# Altium schematic sheet POWER.SchDoc(POWER)
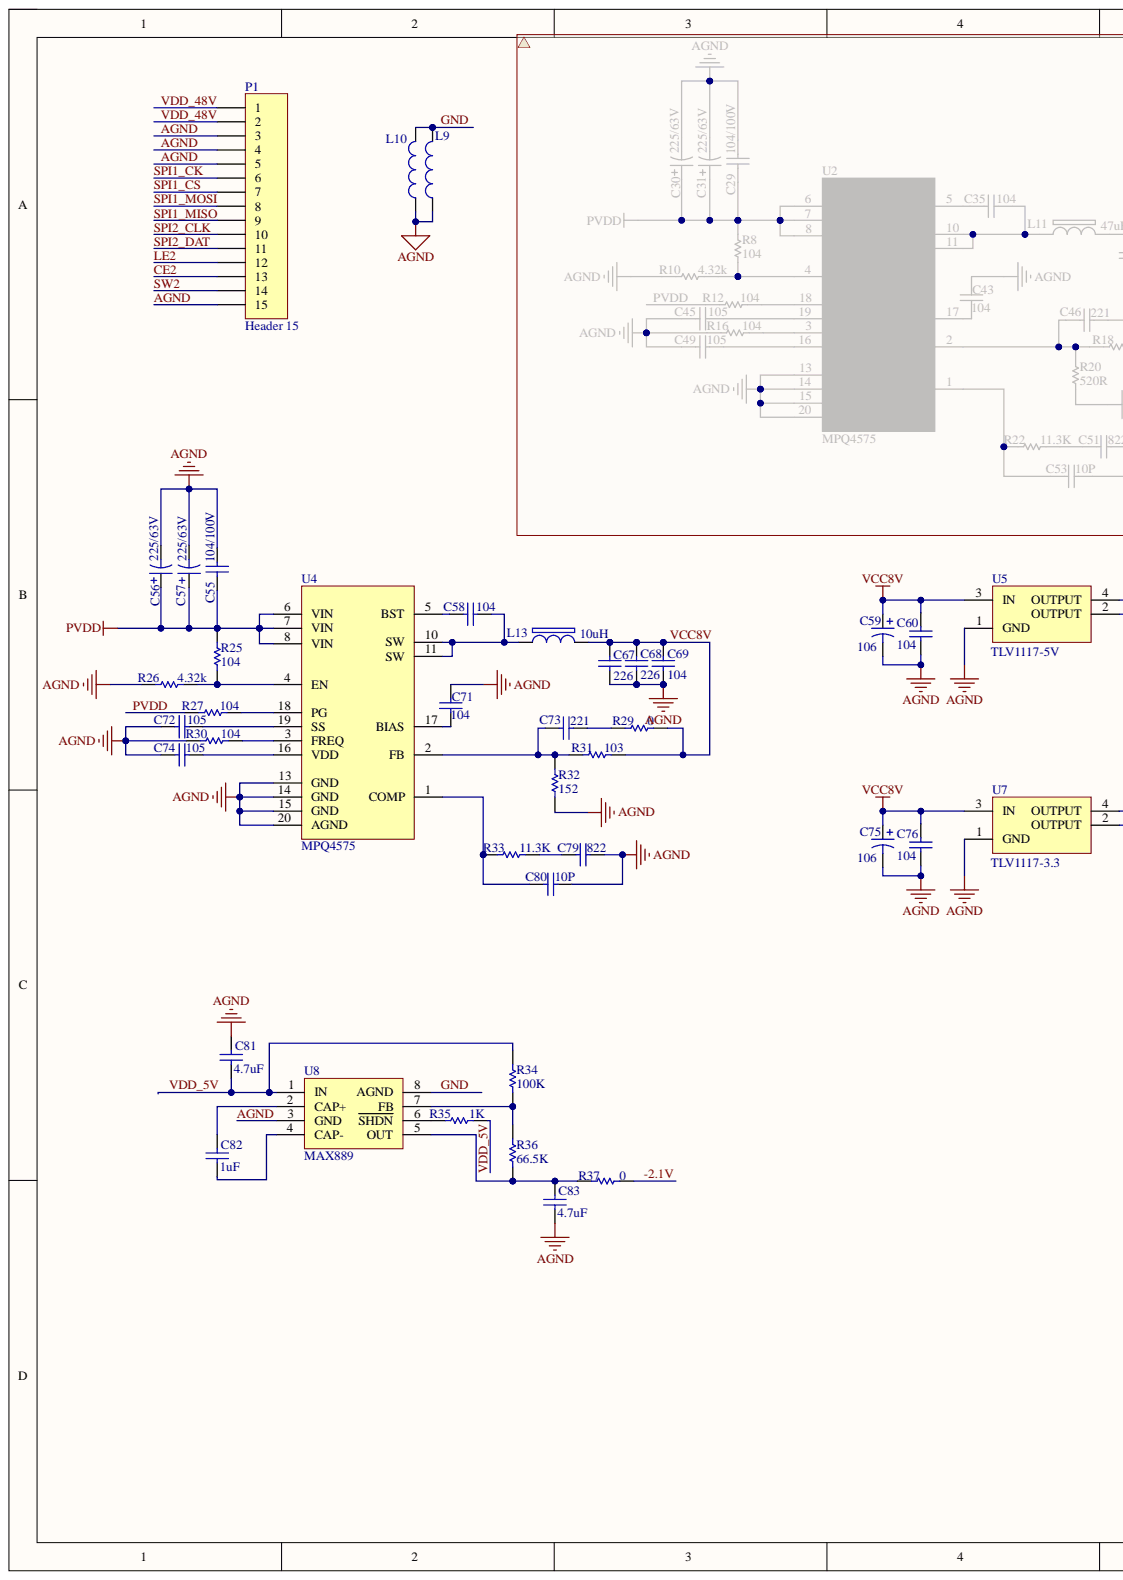
[diagram p0: POWER.SchDoc, left]
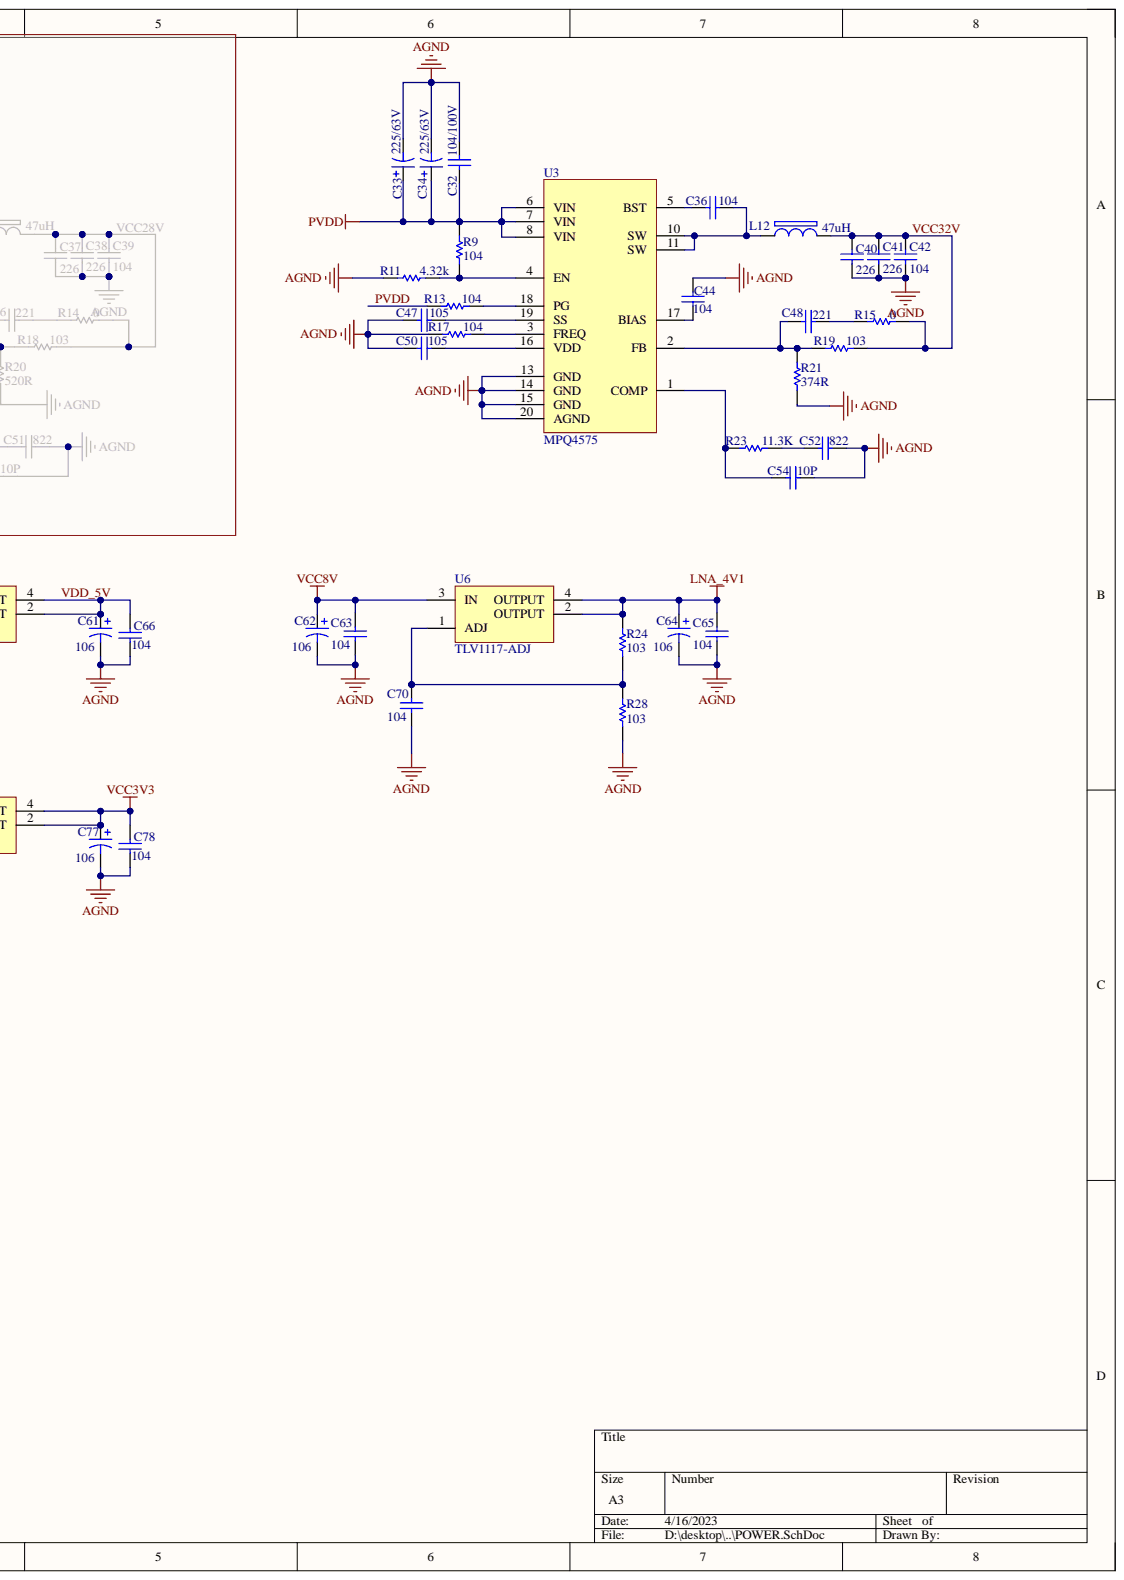
[diagram p1: POWER.SchDoc, right]

## Parts
C32  104/100V  [0603C]
C33  225/63V  [C 1812_L]
C34  225/63V  [C 1812_L]
C36  104  [0603C]
C40  226  [C 1812_L]
C41  226  [C 1812_L]
C42  104  [0603C]
C44  104  [0603C]
C47  105  [0603C]
C48  221  [0603C]
C50  105  [0603C]
C52  822  [0603C]
C54  10P  [0603C]
C55  104/100V  [0603C]
C56  225/63V  [C 1812_L]
C57  225/63V  [C 1812_L]
C58  104  [0603C]
C59  106  [C 1206_L]
C60  104  [0603C]
C61  106  [C 1206_L]
C62  106  [C 1206_L]
C63  104  [0603C]
C64  106  [C 1206_L]
C65  104  [0603C]
C66  104  [0603C]
C67  226  [C 1812_L]
C68  226  [C 1812_L]
C69  104  [0603C]
C70  104  [0603C]
C71  104  [0603C]
C72  105  [0603C]
C73  221  [0603C]
C74  105  [0603C]
C75  106  [C 1206_L]
C76  104  [0603C]
C77  106  [C 1206_L]
C78  104  [0603C]
C79  822  [0603C]
C80  10P  [0603C]
C81  4.7uF  [0603C]
C82  1uF  [0603C]
C83  4.7uF  [0603C]
L9  (no value)  [0603L]
L10  (no value)  [0603L]
L12  47uH  [CD106]
L13  10uH  [CD53]
P1  Header 15  [MDC1-15SW1]
R9  104  [0603R]
R11  4.32k  [0603R]
R13  104  [0603R]
R15  0  [0603R]
R17  104  [0603R]
R19  103  [0603R]
R21  374R  [0603R]
R23  11.3K  [0603R]
R24  103  [0603R]
R25  104  [0603R]
R26  4.32k  [0603R]
R27  104  [0603R]
R28  103  [0603R]
R29  0  [0603R]
R30  104  [0603R]
R31  103  [0603R]
R32  152  [0603R]
R33  11.3K  [0603R]
R34  100K  [0603R]
R35  1K  [0603R]
R36  66.5K  [0603R]
R37  0  [0603R]
U3  MPQ4575  [TSSOP-20]
U4  MPQ4575  [TSSOP-20]
U5  TLV1117-5V  [SOT223]
U6  TLV1117-ADJ  [SOT223]
U7  TLV1117-3.3  [SOT223]
U8  MAX889  [SOIC-8]

## Nets
LNA_4V1: C64.1 C65.2 R24.1 U6.2(OUTPUT) U6.4(OUTPUT)
VCC3V3: C77.1 C78.2 U7.2(OUTPUT) U7.4(OUTPUT)
-2.1V: R37.2
AGND: C32.2 C33.2 C34.2 C40.2 C41.2 C42.2 C44.2 C47.2 C50.2 C52.1 C54.1 C55.2 C56.2 C57.2 C59.2 C60.1 C61.2 C62.2 C63.1 C64.2 C65.1 C66.1 C67.2 C68.2 C69.2 C70.1 C71.2 C72.2 C74.2 C75.2 C76.1 C77.2 C78.1 C79.1 C80.1 C81.1 C83.2 L9.1 L10.1 P1.3 P1.4 P1.5 P1.15 R11.2 R17.2 R21.1 R26.2 R28.2 R30.2 R32.1 U3.13(GND) U3.14(GND) U3.15(GND) U3.20(AGND) U4.13(GND) U4.14(GND) U4.15(GND) U4.20(AGND) U5.1(GND) U7.1(GND) U8.3(GND)
CE2: P1.13
GND: L9.2 L10.2 U8.8(AGND)
LE2: P1.12
PVDD: C32.1 C33.1 C34.1 C55.1 C56.1 C57.1 R9.2 R13.2 R25.2 R27.2 U3.6(VIN) U3.7(VIN) U3.8(VIN) U4.6(VIN) U4.7(VIN) U4.8(VIN)
SPI1_CK: P1.6
SPI1_CS: P1.7
SPI1_MISO: P1.9
SPI1_MOSI: P1.8
SPI2_CLK: P1.10
SPI2_DAT: P1.11
SW2: P1.14
VCC8V: C59.1 C60.2 C62.1 C63.2 C67.1 C68.1 C69.1 C75.1 C76.2 L13.2 R29.1 R31.1 U5.3(IN) U6.3(IN) U7.3(IN)
VCC32V: C40.1 C41.1 C42.1 L12.2 R15.1 R19.1
VDD_5V: C61.1 C66.2 C81.2 R34.2 R35.1 U5.2(OUTPUT) U5.4(OUTPUT) U8.1(IN)
VDD_48V: P1.1 P1.2
NetC36_1: C36.1 U3.5(BST)
NetC36_2: C36.2 L12.1 U3.10(SW) U3.11(SW)
NetC44_1: C44.1 U3.17(BIAS)
NetC47_1: C47.1 U3.19(SS)
NetC48_1: C48.1 R15.2
NetC48_2: C48.2 R19.2 R21.2 U3.2(FB)
NetC50_1: C50.1 U3.16(VDD)
NetC52_2: C52.2 R23.1
NetC54_2: C54.2 R23.2 U3.1(COMP)
NetC58_1: C58.1 U4.5(BST)
NetC58_2: C58.2 L13.1 U4.10(SW) U4.11(SW)
NetC70_2: C70.2 R24.2 R28.1 U6.1(ADJ)
NetC71_1: C71.1 U4.17(BIAS)
NetC72_1: C72.1 U4.19(SS)
NetC73_1: C73.1 R29.2
NetC73_2: C73.2 R31.2 R32.2 U4.2(FB)
NetC74_1: C74.1 U4.16(VDD)
NetC79_2: C79.2 R33.1
NetC80_2: C80.2 R33.2 U4.1(COMP)
NetC82_1: C82.1 U8.2(CAP+)
NetC82_2: C82.2 U8.4(CAP-)
NetC83_1: C83.1 R36.1 R37.1 U8.5(OUT)
NetR9_1: R9.1 R11.1 U3.4(EN)
NetR13_1: R13.1 U3.18(PG)
NetR17_1: R17.1 U3.3(FREQ)
NetR25_1: R25.1 R26.1 U4.4(EN)
NetR27_1: R27.1 U4.18(PG)
NetR30_1: R30.1 U4.3(FREQ)
NetR34_1: R34.1 R36.2 U8.7(FB)
NetR35_2: R35.2 U8.6(SHDN)

## Library data of the parts
C32 C36 C42 C44 C55 C58 C60 C63 C65 C66 C69-C71 C76 C78 = Cap: 08050001; Value: 100pF; Component Kind: Standard; LatestRevisionDate: 17-Jul-2002; LatestRevisionNote: Re-released for DXP Platform.; Library Name: Miscellaneous Devices.IntLib; PackageDescription: Radial Cap, Thru-Hole; 2 Leads; 0.3 in Pin Spacing; PackageReference: RAD-0.3; Published: 8-Jun-2000; Signal Integrity: Cap; Simulation: CAP; Publisher: Altium Limited
C33 C34 C56 C57 = Cap Pol1: 08070019; Value: 100pF; Component Kind: Standard; LatestRevisionDate: 17-Jul-2002; LatestRevisionNote: Re-released for DXP Platform.; Library Name: Miscellaneous Devices.IntLib; PackageDescription: Polarized Capacitor (Radial); 2 Leads; PackageReference: RB7.6-15; Published: 8-Jun-2000; Signal Integrity: Cap Pol; Simulation: CAP; Publisher: Altium Limited
C40 C41 C67 C68 = Cap: 08050051; Value: 100pF; Component Kind: Standard; LatestRevisionDate: 17-Jul-2002; LatestRevisionNote: Re-released for DXP Platform.; Library Name: Miscellaneous Devices.IntLib; PackageDescription: Radial Cap, Thru-Hole; 2 Leads; 0.3 in Pin Spacing; PackageReference: RAD-0.3; Published: 8-Jun-2000; Signal Integrity: Cap; Simulation: CAP; Publisher: Altium Limited
C47 C50 C72 C74 = Cap: 08050005; Value: 100pF; Component Kind: Standard; LatestRevisionDate: 17-Jul-2002; LatestRevisionNote: Re-released for DXP Platform.; Library Name: Miscellaneous Devices.IntLib; PackageDescription: Radial Cap, Thru-Hole; 2 Leads; 0.3 in Pin Spacing; PackageReference: RAD-0.3; Published: 8-Jun-2000; Signal Integrity: Cap; Simulation: CAP; Publisher: Altium Limited
C48 C73 = Cap: 08050020; Value: 100pF; Component Kind: Standard; LatestRevisionDate: 17-Jul-2002; LatestRevisionNote: Re-released for DXP Platform.; Library Name: Miscellaneous Devices.IntLib; PackageDescription: Radial Cap, Thru-Hole; 2 Leads; 0.3 in Pin Spacing; PackageReference: RAD-0.3; Published: 8-Jun-2000; Signal Integrity: Cap; Simulation: CAP; Publisher: Altium Limited
C52 C79 = Cap: 90020565; Value: 100pF; Component Kind: Standard; LatestRevisionDate: 17-Jul-2002; LatestRevisionNote: Re-released for DXP Platform.; Library Name: Miscellaneous Devices.IntLib; PackageDescription: Radial Cap, Thru-Hole; 2 Leads; 0.3 in Pin Spacing; PackageReference: RAD-0.3; Published: 8-Jun-2000; Signal Integrity: Cap; Simulation: CAP; Publisher: Altium Limited
C54 C80 = Cap: 08050009; Value: 100pF; Component Kind: Standard; LatestRevisionDate: 17-Jul-2002; LatestRevisionNote: Re-released for DXP Platform.; Library Name: Miscellaneous Devices.IntLib; PackageDescription: Radial Cap, Thru-Hole; 2 Leads; 0.3 in Pin Spacing; PackageReference: RAD-0.3; Published: 8-Jun-2000; Signal Integrity: Cap; Simulation: CAP; Publisher: Altium Limited
C59 C62 C64 = Cap Pol1: Value: 100pF; Component Kind: Standard; LatestRevisionDate: 17-Jul-2002; LatestRevisionNote: Re-released for DXP Platform.; Library Name: Miscellaneous Devices.IntLib; PackageDescription: Polarized Capacitor (Radial); 2 Leads; PackageReference: RB7.6-15; Published: 8-Jun-2000; Signal Integrity: Cap Pol; Simulation: CAP; Publisher: Altium Limited
C61 C77 = Cap Pol1: 90020588; Value: 100pF; Component Kind: Standard; LatestRevisionDate: 17-Jul-2002; LatestRevisionNote: Re-released for DXP Platform.; Library Name: Miscellaneous Devices.IntLib; PackageDescription: Polarized Capacitor (Radial); 2 Leads; PackageReference: RB7.6-15; Published: 8-Jun-2000; Signal Integrity: Cap Pol; Simulation: CAP; Publisher: Altium Limited
C75 = Cap Pol1: 90020154; Value: 100pF; Component Kind: Standard; LatestRevisionDate: 17-Jul-2002; LatestRevisionNote: Re-released for DXP Platform.; Library Name: Miscellaneous Devices.IntLib; PackageDescription: Polarized Capacitor (Radial); 2 Leads; PackageReference: RB7.6-15; Published: 8-Jun-2000; Signal Integrity: Cap Pol; Simulation: CAP; Publisher: Altium Limited
C81-C83 = Cap: Capacitor; Component Kind: Standard; LatestRevisionDate: 17-Jul-2002; LatestRevisionNote: Re-released for DXP Platform.; Library Name: Miscellaneous Devices.IntLib; PackageDescription: Radial Cap, Thru-Hole; 2 Leads; 0.3 in Pin Spacing; PackageReference: RAD-0.3; Published: 8-Jun-2000; Signal Integrity: Cap; Simulation: CAP; Publisher: Altium Limited
L9 L10 = Inductor: GNSS; Code_IEC: 1005; Code_JEITA: 0402; Component Kind: Standard; LatestRevisionDate: 29-May-2009; LatestRevisionNote: IPC-7351 Footprint Added.; Library Name: Miscellaneous Devices.IntLib; PackageDescription: Chip Inductor; PackageReference: 0402-A; Published: 8-Jun-2000; Signal Integrity: Inductor; Simulation: INDUCTOR; Publisher: Altium Limited
L12 L13 = L: 90021973; Bottom: 40; Right: 20; Component Kind: Standard; Library Name: iDste_A.SCHLIB
P1 = Header 15: Header, 15-Pin; Component Kind: Standard; LatestRevisionDate: 17-Jul-2002; LatestRevisionNote: Re-released for DXP Platform.; Library Name: Miscellaneous Connectors.IntLib; Signal Integrity: Connector; Publisher: Altium Limited
R9 R13 R17 R25 R27 R30 = Res1: 07020007; Value: 1K; Component Kind: Standard; LatestRevisionDate: 17-Jul-2002; LatestRevisionNote: Re-released for DXP Platform.; Library Name: Miscellaneous Devices.IntLib; PackageDescription: Axial Device, Thru-Hole; 2 Leads; 0.3 in Pin Spacing; PackageReference: AXIAL-0.3; Published: 8-Jun-2000; Signal Integrity: Res; Simulation: RESISTOR; Publisher: Altium Limited
R11 R26 = Res1: 90021976; Value: 1K; Component Kind: Standard; LatestRevisionDate: 17-Jul-2002; LatestRevisionNote: Re-released for DXP Platform.; Library Name: Miscellaneous Devices.IntLib; PackageDescription: Axial Device, Thru-Hole; 2 Leads; 0.3 in Pin Spacing; PackageReference: AXIAL-0.3; Published: 8-Jun-2000; Signal Integrity: Res; Simulation: RESISTOR; Publisher: Altium Limited
R15 R29 = Res1: 07020011; Value: 1K; Component Kind: Standard; LatestRevisionDate: 17-Jul-2002; LatestRevisionNote: Re-released for DXP Platform.; Library Name: Miscellaneous Devices.IntLib; PackageDescription: Axial Device, Thru-Hole; 2 Leads; 0.3 in Pin Spacing; PackageReference: AXIAL-0.3; Published: 8-Jun-2000; Signal Integrity: Res; Simulation: RESISTOR; Publisher: Altium Limited
R19 R24 R28 R31 = Res1: 07020008; Value: 1K; Component Kind: Standard; LatestRevisionDate: 17-Jul-2002; LatestRevisionNote: Re-released for DXP Platform.; Library Name: Miscellaneous Devices.IntLib; PackageDescription: Axial Device, Thru-Hole; 2 Leads; 0.3 in Pin Spacing; PackageReference: AXIAL-0.3; Published: 8-Jun-2000; Signal Integrity: Res; Simulation: RESISTOR; Publisher: Altium Limited
R21 R32 = Res1: 90021975; Value: 1K; Component Kind: Standard; LatestRevisionDate: 17-Jul-2002; LatestRevisionNote: Re-released for DXP Platform.; Library Name: Miscellaneous Devices.IntLib; PackageDescription: Axial Device, Thru-Hole; 2 Leads; 0.3 in Pin Spacing; PackageReference: AXIAL-0.3; Published: 8-Jun-2000; Signal Integrity: Res; Simulation: RESISTOR; Publisher: Altium Limited
R23 R33 = Res1: 90021974; Value: 1K; Component Kind: Standard; LatestRevisionDate: 17-Jul-2002; LatestRevisionNote: Re-released for DXP Platform.; Library Name: Miscellaneous Devices.IntLib; PackageDescription: Axial Device, Thru-Hole; 2 Leads; 0.3 in Pin Spacing; PackageReference: AXIAL-0.3; Published: 8-Jun-2000; Signal Integrity: Res; Simulation: RESISTOR; Publisher: Altium Limited
R34-R37 = Res Semi: Semiconductor Resistor; Component Kind: Standard; LatestRevisionDate: 17-Jul-2002; LatestRevisionNote: Re-released for DXP Platform.; Library Name: Miscellaneous Devices.IntLib; PackageDescription: Axial Device, Thru-Hole; 2 Leads; 0.5 in Pin Spacing; PackageReference: AXIAL-0.5; Published: 8-Jun-2000; Signal Integrity: Res Semi; Simulation: RES; Publisher: Altium Limited
U3 U4 = MPQ4570: Component Kind: Standard; Library Name: iDste_A.SCHLIB
U5 U7 = TLV1117-33IDCY: 90021979; Component Kind: Standard; Library Name: iDste_A.SCHLIB
U6 = TLV1117-ADJ: 90021979; Component Kind: Standard; Library Name: iDste_A.SCHLIB
U8 = MAX889: Component Kind: Standard; Library Name: TR_LIB.SchLib
